# Altium schematic sheet 03io.SchDoc
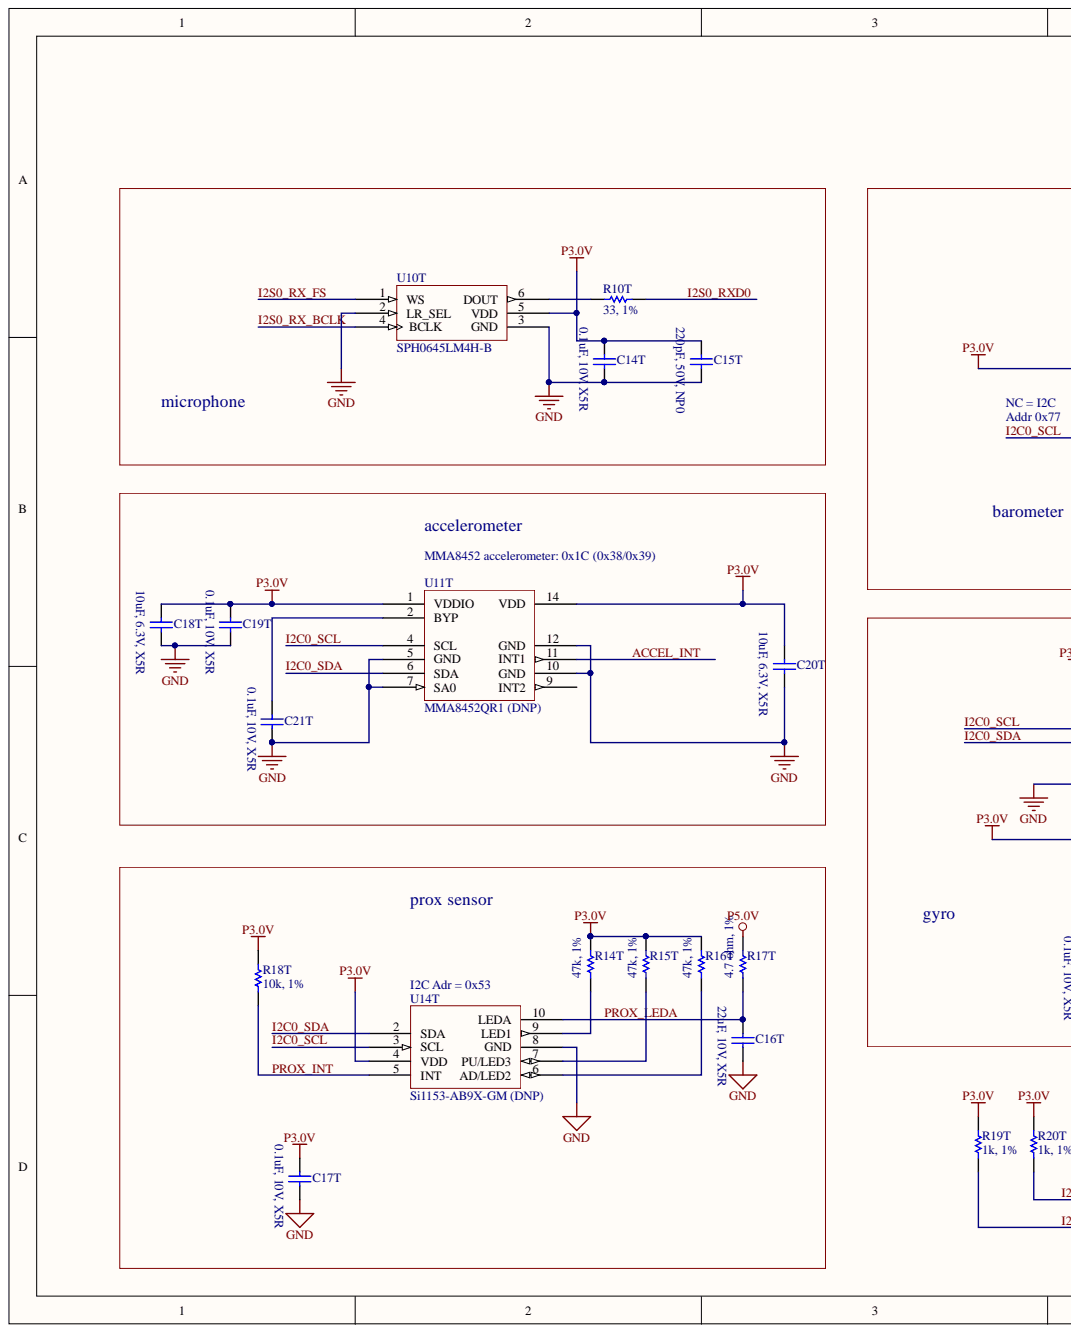
[diagram p0: 03io.SchDoc, left]
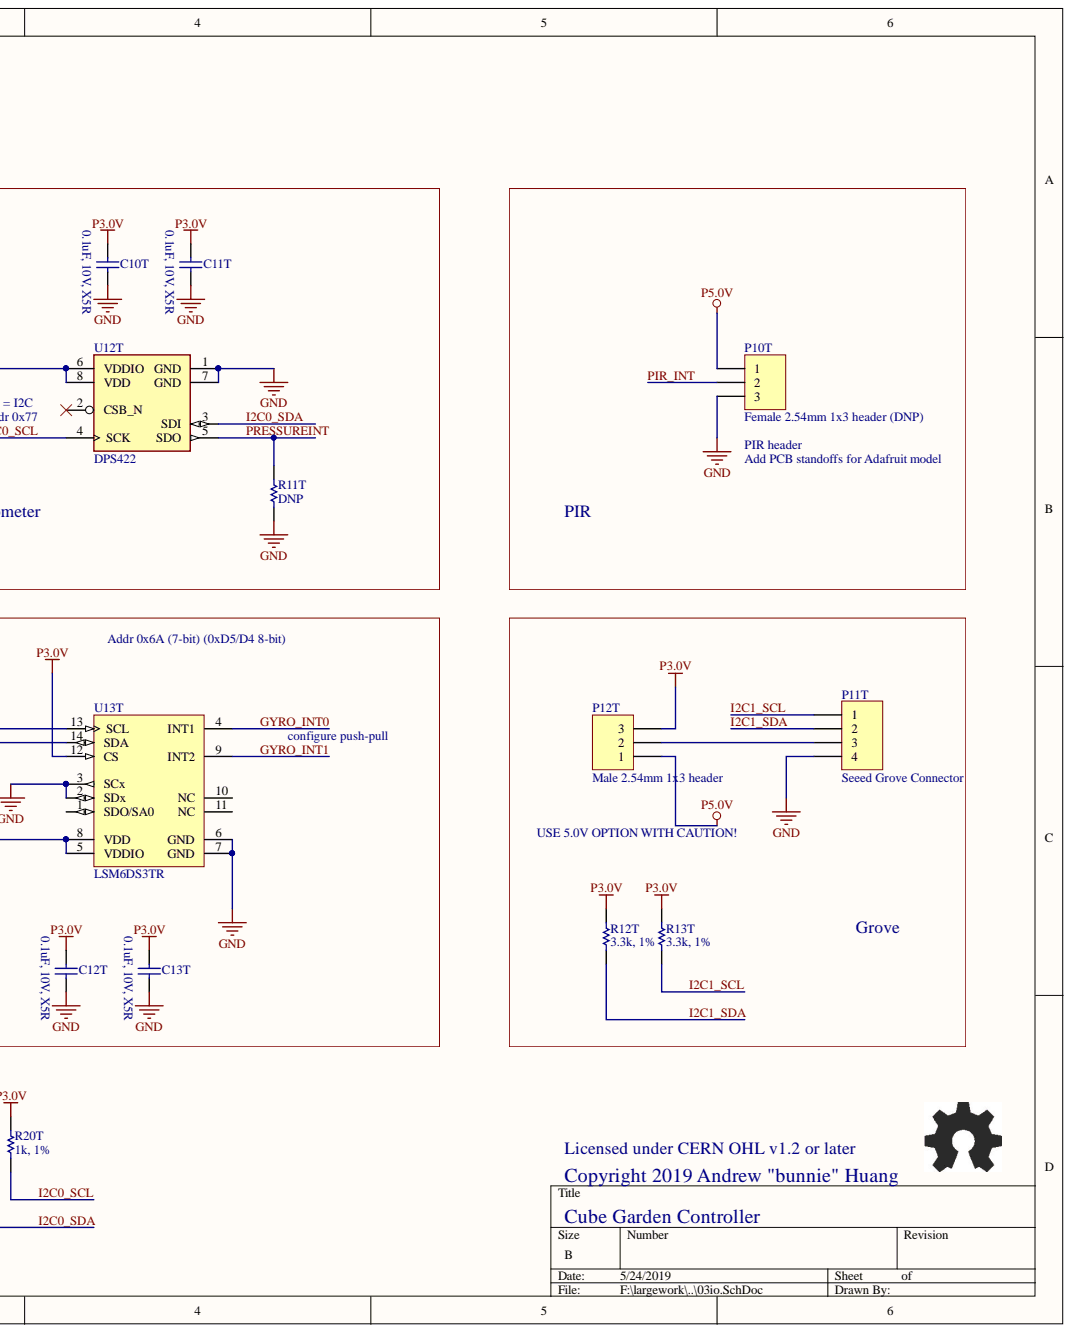
[diagram p1: 03io.SchDoc, right]

## Parts
C10T  0.1uF, 10V, X5R  [B_CAP0402]
C11T  0.1uF, 10V, X5R  [B_CAP0402]
C12T  0.1uF, 10V, X5R  [B_CAP0402]
C13T  0.1uF, 10V, X5R  [B_CAP0402]
C14T  0.1uF, 10V, X5R  [B_CAP0402]
C15T  220pF, 50V, NP0  [B_CAP0402]
C16T  22uF, 10V, X5R  [CAPC2012N]
C17T  0.1uF, 10V, X5R  [B_CAP0402]
C18T  10uF, 6.3V, X5R  [B_CAP0603]
C19T  0.1uF, 10V, X5R  [B_CAP0402]
C20T  10uF, 6.3V, X5R  [B_CAP0603]
C21T  0.1uF, 10V, X5R  [B_CAP0402]
P10T  Female 2.54mm 1x3 header (DNP)  [HDR1X3]
P11T  Seeed Grove Connector  VENDOR: Seeed  [GROVE_TH]
P12T  Male 2.54mm 1x3 header  [HDR1X3]
R10T  33, 1%  [B_RES0402]
R11T  DNP  [B_RES0402]
R12T  3.3k, 1%  [B_RES0402]
R13T  3.3k, 1%  [B_RES0402]
R14T  47k, 1%  [B_RES0402]
R15T  47k, 1%  [B_RES0402]
R16T  47k, 1%  [B_RES0402]
R17T  4.7 ohm, 1%  [B_RES0603]
R18T  10k, 1%  [B_RES0402]
R19T  1k, 1%  [B_RES0402]
R20T  1k, 1%  [B_RES0402]
U10T  SPH0645LM4H-B  [KNOWLES_SPH0645LM4H-B]
U11T  MMA8452QR1 (DNP)  [FSC_QFN_3x3x1_16_2077-02]
U12T  DPS422  [PSON65P200X250X80R-8USR]
U13T  LSM6DS3TR  [LGA-14_2500X3000X860_N]
U14T  Si1153-AB9X-GM (DNP)  [SILABS_10LGA]

## Nets
GND: C10T.2 C11T.2 C12T.2 C13T.2 C14T.2 C15T.2 C16T.2 C17T.2 C18T.2 C19T.2 C20T.2 C21T.2 P10T.3 P11T.4 R11T.1 U10T.2(LR_SEL) U10T.3(GND) U11T.5(GND) U11T.7(SA0) U11T.10(GND) U11T.12(GND) U12T.1(GND) U12T.7(GND) U13T.2(SDx) U13T.3(SCx) U13T.6(GND) U13T.7(GND) U14T.8(GND)
P3.0V: C10T.1 C11T.1 C12T.1 C13T.1 C14T.1 C15T.1 C17T.1 C18T.1 C19T.1 C20T.1 P12T.3 R12T.1 R13T.1 R14T.2 R15T.2 R16T.2 R18T.1 R19T.1 R20T.1 U10T.5(VDD) U11T.1(VDDIO) U11T.14(VDD) U12T.6(VDDIO) U12T.8(VDD) U13T.5(VDDIO) U13T.8(VDD) U13T.12(CS) U14T.4(VDD)
P5.0V: P10T.1 P12T.1 R17T.2
ACCEL_INT: U11T.11(INT1)
GYRO_INT0: U13T.4(INT1)
GYRO_INT1: U13T.9(INT2)
I2C0_SCL: R20T.2 U11T.4(SCL) U12T.4(SCK) U13T.13(SCL) U14T.3(SCL)
I2C0_SDA: R19T.2 U11T.6(SDA) U12T.3(SDI) U13T.14(SDA) U14T.2(SDA)
I2C1_SCL: P11T.1 R13T.2
I2C1_SDA: P11T.2 R12T.2
I2S0_RX_BCLK: U10T.4(BCLK)
I2S0_RX_FS: U10T.1(WS)
I2S0_RXD0: R10T.2
PIR_INT: P10T.2
PRESSUREINT: R11T.2 U12T.5(SDO)
PROX_INT: R18T.2 U14T.5(INT)
PROX_LEDA: C16T.1 R17T.1 U14T.10(LEDA)
NetC21T_1: C21T.1 U11T.2(BYP)
NetP11T_3: P11T.3 P12T.2
NetR10T_1: R10T.1 U10T.6(DOUT)
NetR14T_1: R14T.1 U14T.9(LED1)
NetR15T_1: R15T.1 U14T.7(PU/LED3)
NetR16T_1: R16T.1 U14T.6(AD/LED2)

Not connected: U11T 9(INT2); U12T 2(CSB_N); U13T 1(SDO/SA0) 10(NC) 11(NC)

## Library data of the parts
C10T C11T C12T C13T C14T C17T C19T C21T = Cap Semi: Capacitor (Semiconductor SIM Model); MPN: CL05A104KP5NNNC; MYPN: C-104-10-X5R-0402; VENDOR: Samsung; Component Kind: Standard; Library Name: Miscellaneous Devices.IntLib; Signal Integrity: Cap Semi; Simulation: CAP
C15T = Cap Semi: Capacitor (Semiconductor SIM Model); MPN: CL05C221JB5NNNC; MYPN: C-221-50-NP0-0402; VENDOR: Samsung; Component Kind: Standard; Library Name: Miscellaneous Devices.IntLib; Signal Integrity: Cap Semi; Simulation: CAP
C16T = Cap Semi: Capacitor (Semiconductor SIM Model); MPN: CL21A226KPCLRNC; MYPN: C-226-10-X5R-0805; VENDOR: Samsung; Component Kind: Standard; Library Name: Miscellaneous Devices.IntLib; Signal Integrity: Cap Semi; Simulation: CAP
C18T C20T = Cap Semi: Capacitor (Semiconductor SIM Model); MPN: CL10A106MQ8NNNC; MYPN: C-106-6.3-X5R-0603; VENDOR: Samsung; Component Kind: Standard; Library Name: Miscellaneous Devices.IntLib; Signal Integrity: Cap Semi; Simulation: CAP
P10T = Header 3: Header, 3-Pin; MYPN: ANY; Component Kind: Standard; LatestRevisionDate: 17-Jul-2002; LatestRevisionNote: Re-released for DXP Platform.; Library Name: Miscellaneous Connectors.IntLib; MPN: DNP; Signal Integrity: Connector; VENDOR: DNP; Publisher: Altium Limited
P11T = Header 4: Header, 4-Pin; MPN: 110990030; MYPN: J-GROVE-TH-VERT; Component Kind: Standard; LatestRevisionDate: 17-Jul-2002; LatestRevisionNote: Re-released for DXP Platform.; Library Name: Miscellaneous Connectors.IntLib; Signal Integrity: Connector; Publisher: Altium Limited
P12T = Header 3: Header, 3-Pin; MPN: 61300311121; MYPN: ANY; VENDOR: Wurth; Component Kind: Standard; LatestRevisionDate: 17-Jul-2002; LatestRevisionNote: Re-released for DXP Platform.; Library Name: Miscellaneous Connectors.IntLib; Signal Integrity: Connector; Publisher: Altium Limited
R10T = Res1: Resistor; MPN: RS02U330FT; MYPN: R-330-1P-1_16W-0402; VENDOR: Fenghua; Component Kind: Standard; Library Name: Miscellaneous Devices.IntLib; Signal Integrity: Res; Simulation: RESISTOR
R11T = Res1: Resistor; VENDOR: ANY; Component Kind: Standard; Library Name: Miscellaneous Devices.IntLib; MPN: DNP; MYPN: DNP; Signal Integrity: Res; Simulation: RESISTOR
R12T R13T = Res1: Resistor; MPN: ANY; MYPN: R-332-1P-1_16W-0402; Component Kind: Standard; Library Name: Miscellaneous Devices.IntLib; Signal Integrity: Res; Simulation: RESISTOR; VENDOR: ANY
R14T R15T R16T = Res1: Resistor; MPN: ANY; MYPN: R-4702-1P-1_16W-0402; Component Kind: Standard; Library Name: Miscellaneous Devices.IntLib; Signal Integrity: Res; Simulation: RESISTOR; VENDOR: ANY
R17T = Res1: Resistor; MPN: ANY; MYPN: R-4R7-1P-1_16W-0603; Component Kind: Standard; Library Name: Miscellaneous Devices.IntLib; Signal Integrity: Res; Simulation: RESISTOR; VENDOR: ANY
R18T = Res1: Resistor; MPN: RS02U103FT; MYPN: R-103-1P-1_16W-0402; VENDOR: Fenghua; Component Kind: Standard; Library Name: Miscellaneous Devices.IntLib; Signal Integrity: Res; Simulation: RESISTOR
R19T R20T = Res1: Resistor; MPN: ANY; MYPN: R-102-1P-1_16W-0402; Component Kind: Standard; Library Name: Miscellaneous Devices.IntLib; Signal Integrity: Res; Simulation: RESISTOR; VENDOR: ANY
U10T = SPH0645LM4H-B: I2S output digital microphone; MYPN: USPH0645LM4H-B; VENDOR: Knowles; Component Kind: Standard; Library Name: commonsch.lib; MPN: SPH0645LM4H-B
U11T = MMA8452QR1: 3-axis, 12-bit/8-bit digital accelerometer; MYPN: UMMA8452QT; VENDOR: Freescale Semiconductor; Component Kind: Standard; Library Name: commonsch.lib; MPN: DNP
U12T = DPS422: Digital Barometric Sensor for Portable and IOT Devices; MPN: DPS422XTSA1; MYPN: U-DPS422XTSA1; VENDOR: Infineon; Component Kind: Standard; Library Name: commonsch-new.SchLib; Package Reference: LGA-8
U13T = LSM6DS3TR: iNEMO Inertial Module: Always-on 3D Accelerometer and 3D Gyroscope, 1.71 to 3.6 V, -40 to 85 degC, 14-Pin LGA, RoHS, Tape and Reel; Lead Finish: GoldOverNickel; Max Processing Temp: 260; MYPN: U-LSM6DS3TR; Number of Axis: 3; Operating Supply Voltage: 1.8volt; Output Type: Digital; Screening Level: Industrial; Sensing Distance: 2|4|6|8|16G; Typical Supply Current: 1.25Milliamps; VENDOR: STMicroelectronics; Component Kind: Standard; Datasheet URL: http://www2.st.com/content/ccc/resource/technical/document/datasheet/a3/f5/4f/ae/8e/44/41/d7/DM00133076.pdf/files/DM00133076.pdf/jcr:content/translations/en.DM00133076.pdf; Datasheet Version: Rev. 8, 02/2016; Library Name: commonsch-new.SchLib; Manufacturer URL: http://www.st.com/; Mounting: SurfaceMount; MPN: LSM6DS3TR; Operating Temperature: -40to85C; Type: AccelerometerandGyroscope
U14T = Si1153-AB9X-GM: Proximity/Ambient Light Sensor IC with I2C Interface; MYPN: U-Si1153-A(A/B)9X-GM(R); Component Kind: Standard; Library Name: commonsch-new.SchLib; MPN: DNP; VENDOR: DNP
